# Altium schematic sheet TOP.SchDoc(TOP)
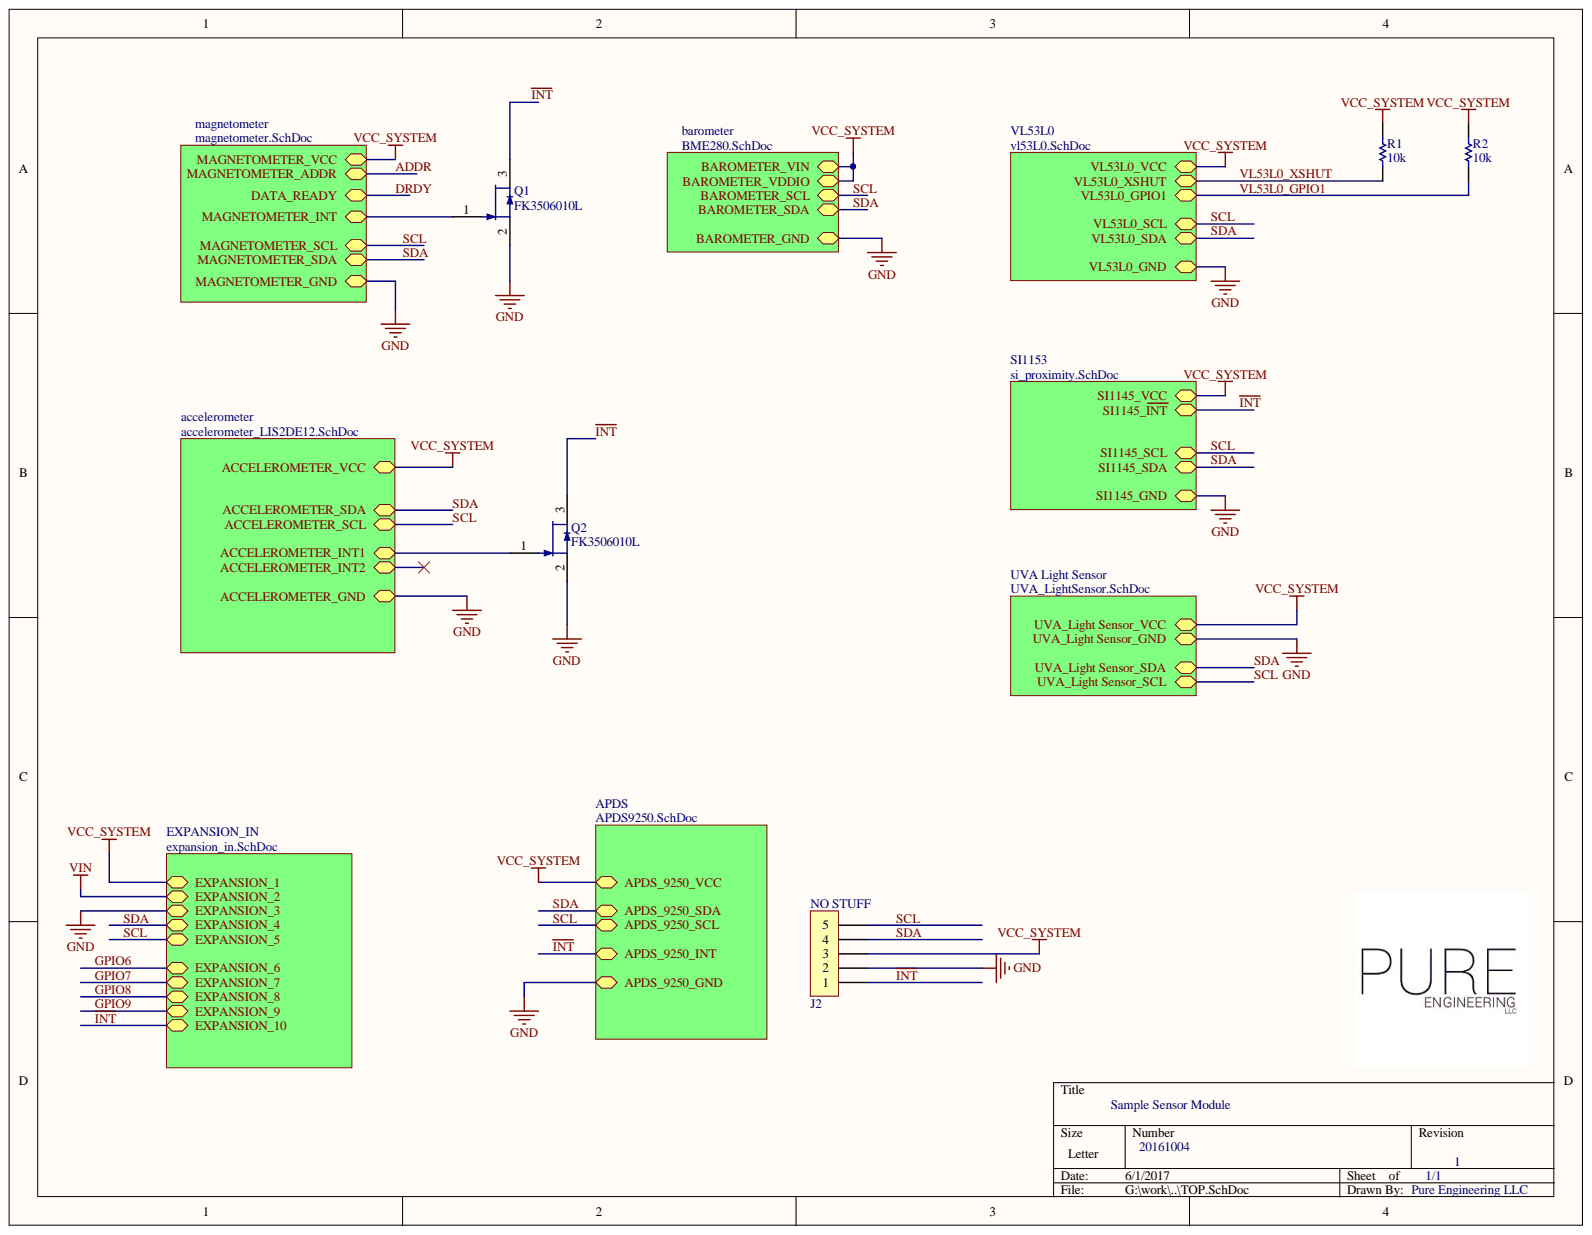
[diagram p0: TOP.SchDoc]

## Parts
J2  NO STUFF  [5X1BreakawayHeader]  (no BOM)
Q1  MANUFACTURER P/N: FK3506010L  [sot23 panasonic]  (hidden comment: PMZB29OUN,315_1)
Q2  MANUFACTURER P/N: FK3506010L  [sot23 panasonic]  (hidden comment: PMZB29OUN,315_1)
R1  value 10k  [0402 RESC1005X40N]  (hidden comment: ERJ-2RKF1002X)
R2  value 10k  [0402 RESC1005X40N]  (hidden comment: ERJ-2RKF1002X)

## Nets
GND: J2.2 Q1.2 Q2.2
VCC_SYSTEM: J2.3 R1.2 R2.2
~{INT}: J2.1 Q1.3 Q2.3
SCL: J2.5
SDA: J2.4
VL53L0_GPIO1: R2.1
VL53L0_XSHUT: R1.1
NetQ1_1: Q1.1
NetQ2_1: Q2.1
ACCELEROMETER_INT2:
ADDR:
DRDY:
GPIO6:
GPIO7:
GPIO8:
GPIO9:
VIN:

## Library data of the parts
J2 = PEC05SAAN: Header, 5 pin 100 mil, Through Hole; MANUFACTURER: SULLINS; COST: 0.63; DISTRIBUTOR: DIGI-KEY; DISTRIBUTOR P/N: S1012E-05-ND; Library Name: SO_SchematicLibrary.SchLib; MANUFACTURER P/N: PEC05SAAN; CREATED BY: SO
Q1 Q2 = PMZB29OUN,315_1: MOSFET N-CH 60V 100MA SMINI3; MANUFACTURER: PANASONIC; VALIDATED BY: NV; Component Kind: Standard; COST: 0.1; DISTRIBUTOR: DIGIKEY; DISTRIBUTOR P/N: FK3506010LCT-ND; Library Name: KL_SchematicLibrary.SchLib; CREATED BY: KL
R1 R2 = ERJ-2RKF1002X: Resistor, 10k Ohm, 1/16W 1% 0402 SMD; MANUFACTURER: PANASONIC; Component Kind: Standard; COST: 0.098; DISTRIBUTOR: DIGI-KEY; DISTRIBUTOR P/N: P10.0KLCT-ND; Library Name: PassiveSchematicLibrary.SchLib; MANUFACTURER P/N: ERJ-2RKF1002X; CREATED BY: SO
